# Altium schematic sheet Lesegerät_RC-Ozillator.SchDoc
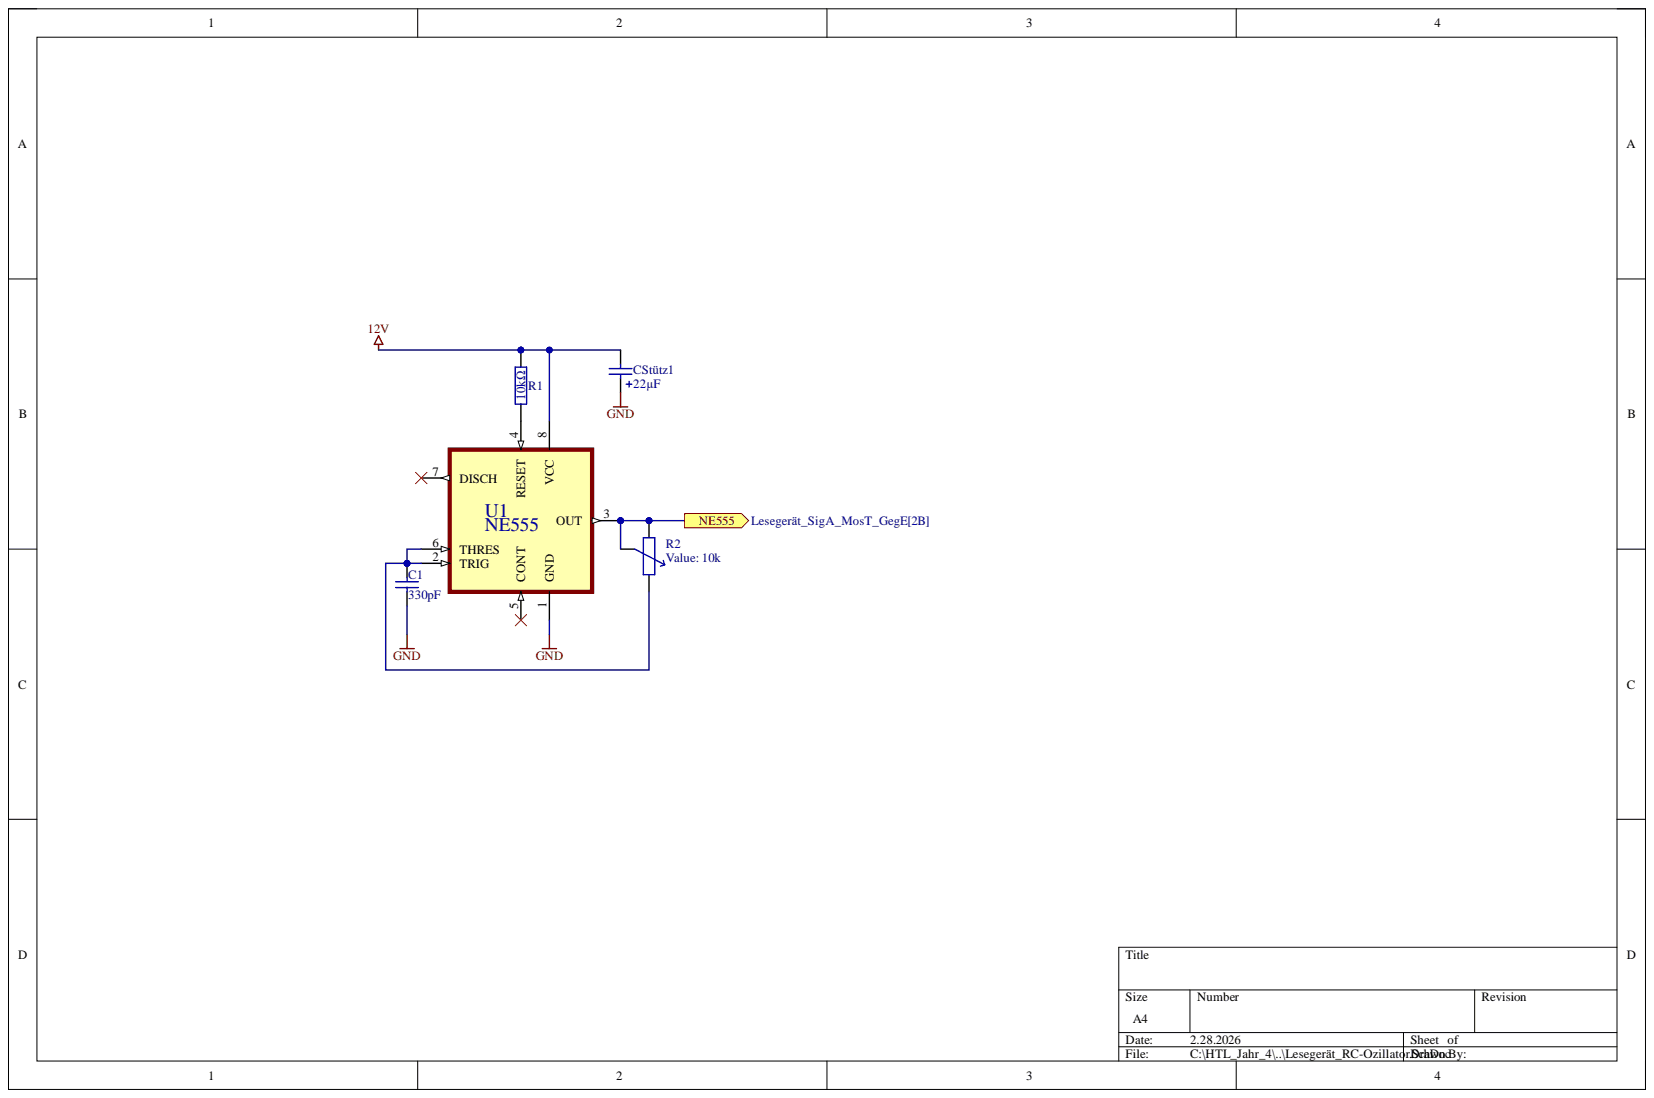
[diagram p0: Lesegerät_RC-Ozillator.SchDoc]

## Parts
C1  330pF  [rad-7.2/2.5/5]
CStütz1  value 22µF  [RB-.1/.2]  (hidden comment: ELKO)
R1  value 10kΩ  [Axial-0.4]  (hidden comment: RES)
R2  value 10k  [PT15]  (hidden comment: POT)
U1  NE555  [DIP794W45P254L959H508Q8]

## Ports
NE555

## Nets
12V: CStütz1.2 R1.2 U1.8(VCC)
GND: C1.2 CStütz1.1 U1.1(GND)
NE555: R2.1 R2.3 U1.3(OUT) ; port NE555
NetC1_1: C1.1 R2.2 U1.2(TRIG) U1.6(THRES)
NetR1_1: R1.1 U1.4(RESET)
NetU1_5: U1.5(CONT)
NetU1_7: U1.7(DISCH)

## Library data of the parts
C1 = KO: Kondensator; Component Kind: Standard; Library Name: HTL-sym-2021_04.schlib; Simulation: Model Name
R1 = RES: Widerstand; Component Kind: Standard; Library Name: HTL-sym-2021_04.schlib; Simulation: Model Name
R2 = POT: Potentiometer; Set Position: 0.5; Component Kind: Standard; Library Name: HTL-sym-2021_04.schlib; Simulation: Model Name
U1 = NE555P: Single Precision Timer; MF: Texas; Check_prices: https://www.snapeda.com/parts/NE555P/Texas+Instruments/view-part/?ref=eda; Component Kind: Standard; Library Name: NE.SCHLIB; MP: NE555P; Package: PDIP-8 Texas; SnapEDA_Link: https://www.snapeda.com/parts/NE555P/Texas+Instruments/view-part/?ref=snap
CStütz1 = ELKO: Component Kind: Standard; Description: Elko; Library Name: HTL-sym-2021_04.schlib; Simulation: Model Name
